# Altium schematic sheet 024_DebugUART2.SchDoc(024_DebugUART2)
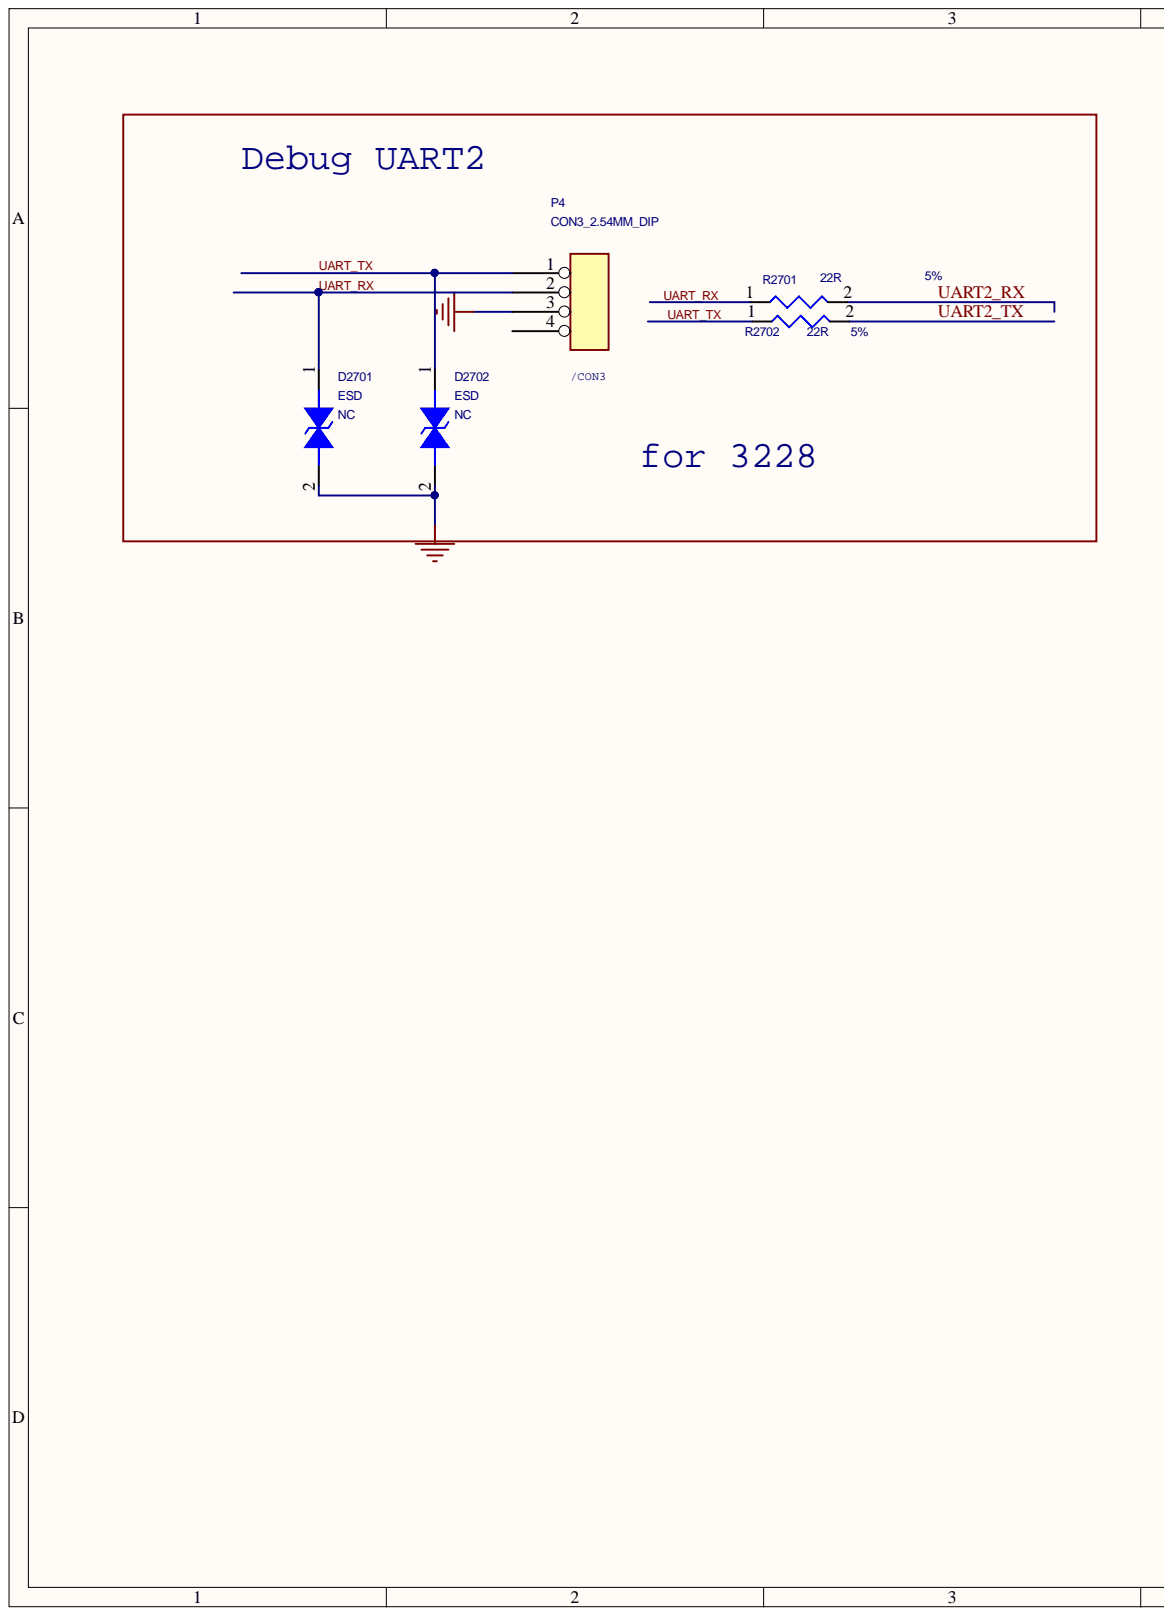
[diagram p0: 024_DebugUART2.SchDoc, left]
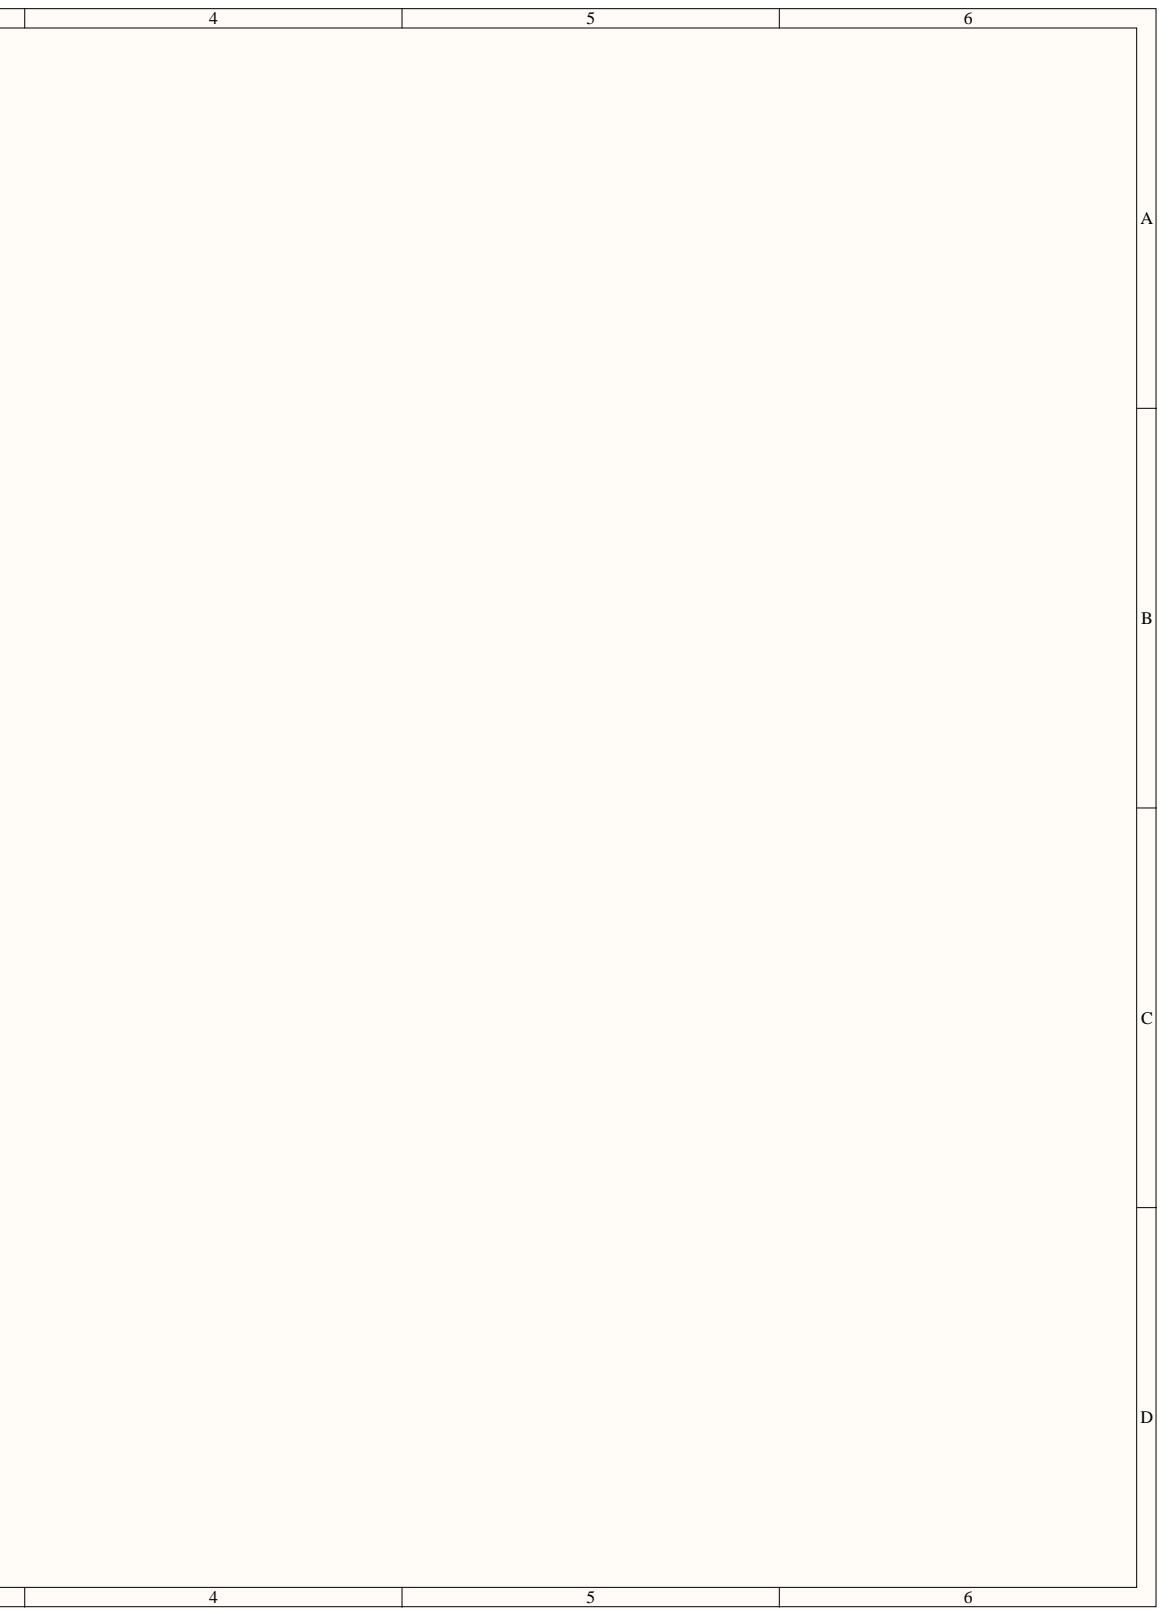
[diagram p1: 024_DebugUART2.SchDoc, right]

## Parts
D2701  NC  OPTION: ESD  [ESD0402]
D2702  NC  OPTION: ESD  [ESD0402]
P4  CON3_2.54MM_DIP  [CON4_2.5MM_DIP]
R2701  22R  Tolerance: 5%  [R0402]
R2702  22R  Tolerance: 5%  [R0402]

## Nets
GND: D2701.2 D2702.2 P4.3
UART2_RX: R2701.2
UART2_TX: R2702.2
UART_RX: D2701.1 P4.2 R2701.1
UART_TX: D2702.1 P4.1 R2702.1

Not connected: P4 4

## Library data of the parts
D2701 D2702 = DIODE BI_DIR TRIG: 1-Line, Bi-directional, Transient Voltage Suppressors; Manufacturer: WillSemi(甲먀군랄); RK PN: ESD5451N; Priority: A; Part Type: ESD_TVS; Manufacturer PN: ESD5451N; Component Kind: Standard; Created by: JJJ
P4 = CON4_2.5MM_DIP_Dup1: option: DNP; Component Kind: Standard
R2701 R2702 = RESISTOR_Dup1: 哥棨슌훡롯量,22R,+/-5%,R0402,1/16W.; Manufacturer: YAGEO; Wattage: 1/16W; RK PN: RC0402JR-0722RL; Priority: A; Part Type: 哥棨슌훡롯量; Manufacturer PN: RC0402JR-0722RL; Component Kind: Standard; Created by: YTL
